# Altium schematic sheet [12]-MCU_INPUTS.SchDoc([12]-MCU_INPUTS)
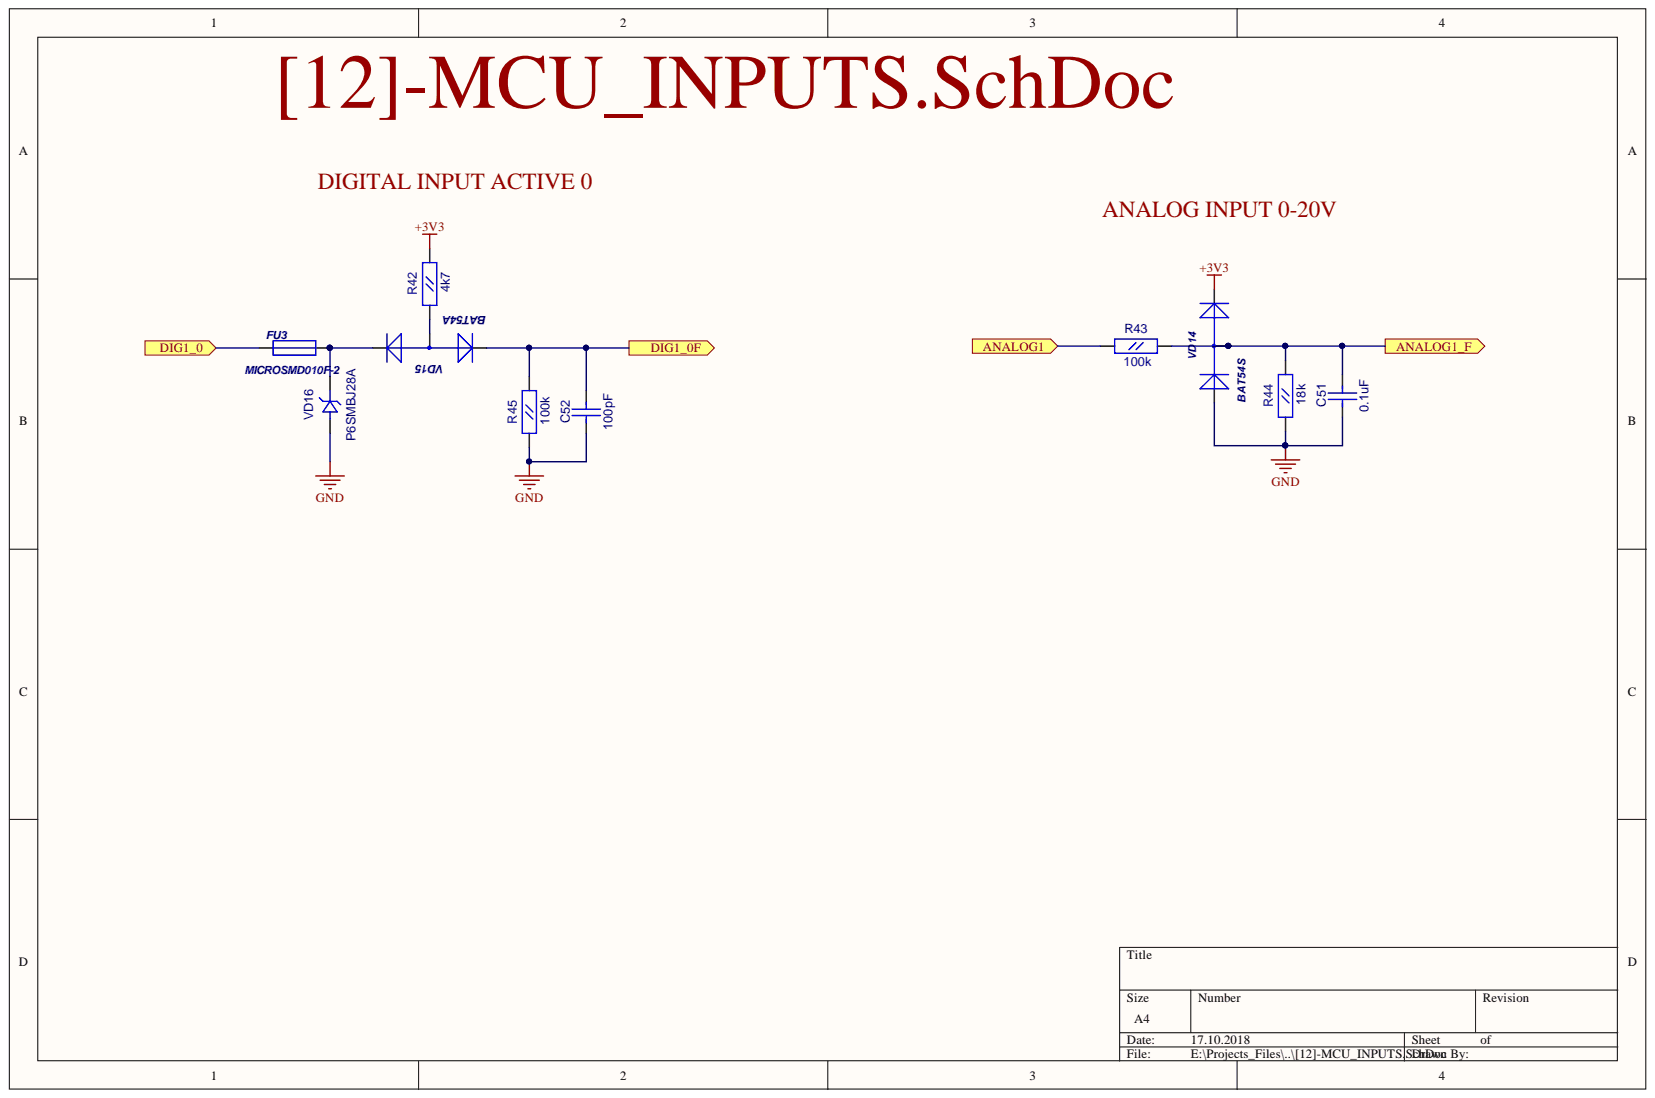
[diagram p0: [12]-MCU_INPUTS.SchDoc]

## Parts
C51  0.1uF  [CC0603]
C52  100pF  [CC0603]
FU3  MICROSMD010F-2  [RC1210]
R42  4k7  [RC0603]
R43  100k  [RC0603]
R44  18k  [RC0603]
R45  100k  [RC0603]
VD14  BAT54S  [SOT-23(GSD)]
VD15  BAT54A  [SOT-23(123)]
VD16  P6SMBJ28A  [SMB]

## Ports
ANALOG1, ANALOG1_F, DIG1_0, DIG1_0F

## Nets
+3V3: R42.2 VD14.2
GND: C51.1 C52.1 R44.1 R45.1 VD14.1 VD16.1
ANALOG1: R43.1 ; port ANALOG1
ANALOG1_F: C51.2 R43.2 R44.2 VD14.3 ; port ANALOG1_F
DIG1_0: FU3.1 ; port DIG1_0
DIG1_0F: C52.2 R45.2 VD15.1 ; port DIG1_0F
NetFU3_2: FU3.2 VD15.2 VD16.2
NetR42_1: R42.1 VD15.3

## Library data of the parts
C51 C52 = CC0603: Description: CC0603; Component Kind: Standard; Library Name: gprs_modem_2.SchLib
  C51: Value: 0.1uF
  C52: Value: 100pF
FU3 = MICROSMD: Value: MICROSMD010F-2; Description: MICROSMD; Component Kind: Standard; Library Name: gprs_modem_2.SchLib
R42-R45 = RC0603: Description: RC0603; Component Kind: Standard; Library Name: gprs_modem_2.SchLib
  R42: Value: 4k7
  R43 R45: Value: 100k
  R44: Value: 18k
VD14 = BAT54S: 1.0 Ampere General Purpose Rectifier; ComponentField6: Published 8-Jun-2000, Modified 11-July-2000: SIM Model Added.; Simulation: DIODE; Component Kind: Standard; Library Name: gprs_modem_2.SchLib
VD15 = BAT54A: 1.0 Ampere General Purpose Rectifier; ComponentField6: Published 8-Jun-2000, Modified 11-July-2000: SIM Model Added.; Simulation: DIODE; Component Kind: Standard; Library Name: gprs_modem_2.SchLib
VD16 = SMBJ: Value: P6SMBJ28A; Description: SMBJ; Component Kind: Standard; Library Name: gprs_modem_2.SchLib
